# Altium schematic sheet ShapingAmp.SchDoc
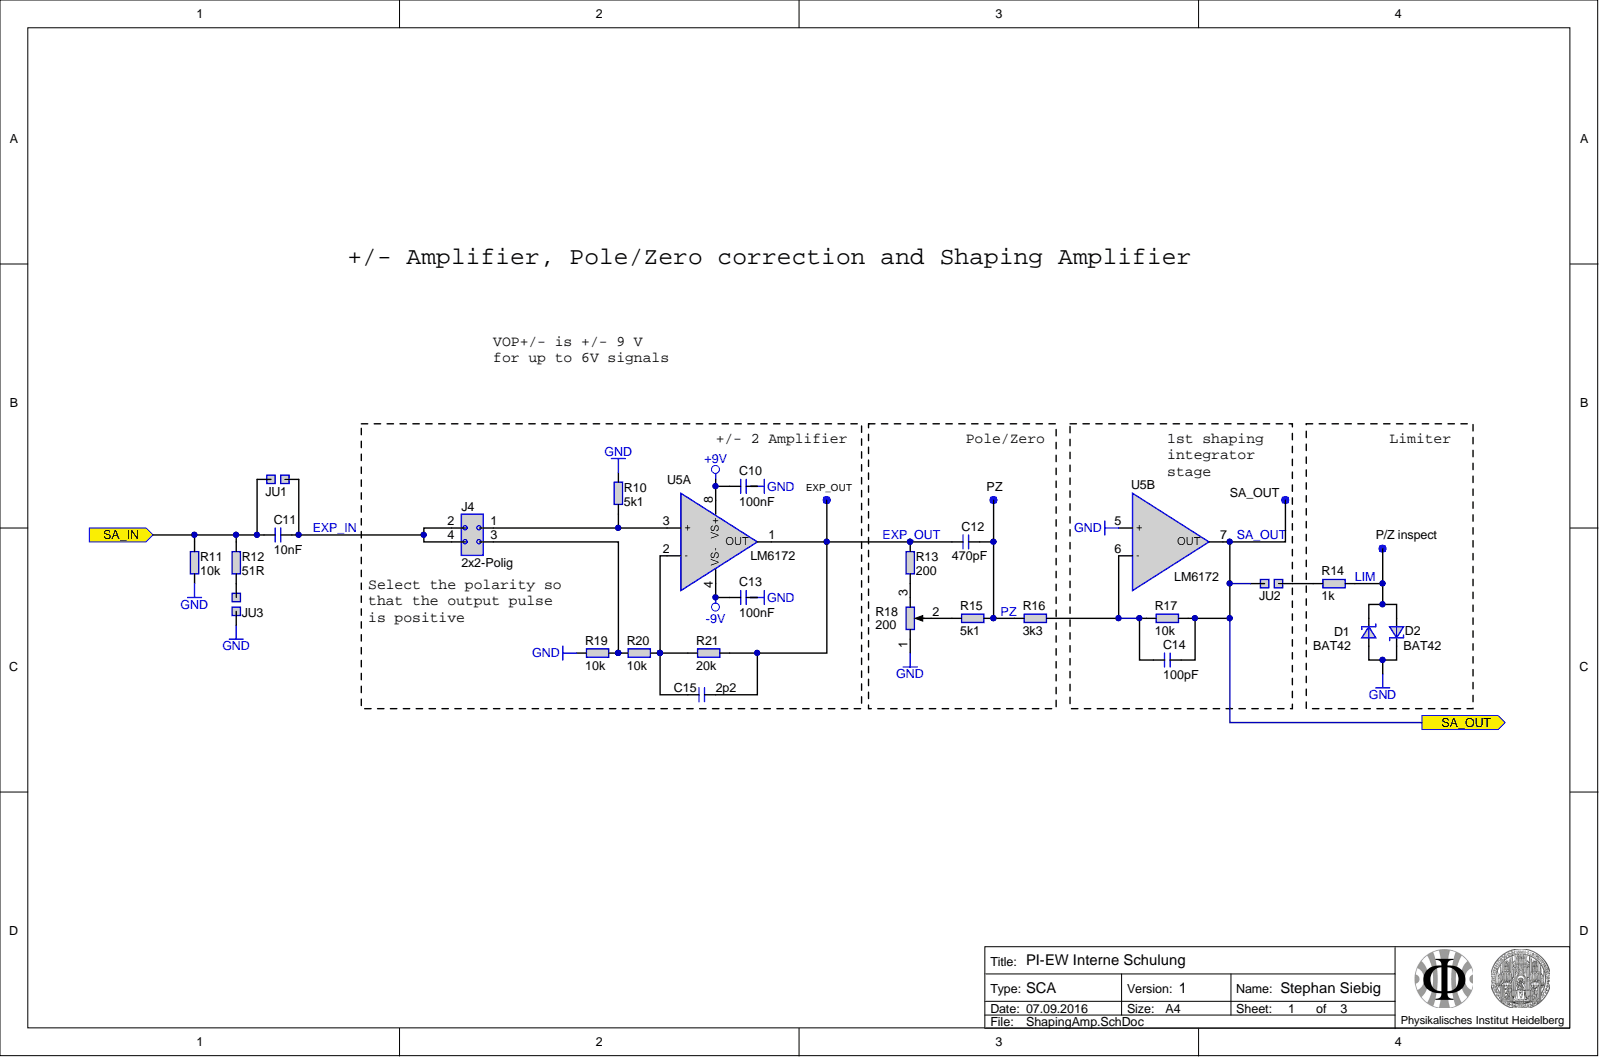
[diagram p0: ShapingAmp.SchDoc]

## Parts
C10  value 100nF  [0805SMD]
C11  value 10nF  [0805SMD]
C12  value 470pF  [0805SMD]
C13  value 100nF  [0805SMD]
C14  value 100pF  [0805SMD]
C15  value 2p2  [0805SMD]
D1  BAT42  [D-1206SMD]
D2  BAT42  [D-1206SMD]
EXP_OUT  PAD  [PAD-2MM_1MM]  (value not drawn)  (no BOM)
J4  value 2x2-Polig  [ST-2X2_2.54MM]  (no BOM)
JU1  (no value)  [ST-1X2_2.54MM]  (no BOM)
JU2  (no value)  [ST-1X2_2.54MM]  (no BOM)
JU3  (no value)  [ST-1X2_2.54MM]  (no BOM)
P/Z_inspect  PAD  [PAD-2MM_1MM]  (value not drawn)  (no BOM)
PZ  PAD  [PAD-2MM_1MM]  (value not drawn)  (no BOM)
R10  value 5k1  [R-0603SMD]
R11  value 10k  [R-0603SMD]
R12  value 51R  [R-0603SMD]
R13  value 200  [R-0603SMD]
R14  value 1k  [R-0603SMD]
R15  value 5k1  [R-0603SMD]
R16  value 3k3  [R-0603SMD]
R17  value 10k  [R-0603SMD]
R18  value 200  [PO-3299W]  (hidden comment: 3266P/W-1-XXX)
R19  value 10k  [R-0603SMD]
R20  value 10k  [R-0603SMD]
R21  value 20k  [R-0603SMD]
SA_OUT  PAD  [PAD-2MM_1MM]  (value not drawn)  (no BOM)
U5  LM6172  [DIP-8]  (parts A,B)

## Ports
SA_IN, SA_OUT

## Nets
+9V: C10.1 U5.8(VS+)
-9V: C13.1 U5.4(VS-)
GND: C10.2 C13.2 D1.2 D2.1 JU3.2 R10.2 R11.1 R18.1 R19.2 U5.5(+)
EXP_IN: C11.2 J4.2 J4.4 JU1.1
EXP_OUT: C12.1 C15.2 EXP_OUT.1 R13.2 R21.1 U5.1(OUT)
LIM: D1.1 D2.2 P/Z_inspect.1 R14.1
PZ: C12.2 PZ.1 R15.1 R16.2
SA_IN: C11.1 JU1.2 R11.2 R12.2 ; port SA_IN
SA_OUT: C14.2 JU2.2 R17.1 SA_OUT.1 U5.7(OUT) ; port SA_OUT
NetC14_1: C14.1 R16.1 R17.2 U5.6(-)
NetC15_1: C15.1 R20.1 R21.2 U5.2(-)
NetJ4_1: J4.1 R10.1 U5.3(+)
NetJ4_3: J4.3 R19.1 R20.2
NetJU2_1: JU2.1 R14.2
NetJU3_1: JU3.1 R12.1
NetR13_1: R13.1 R18.3
NetR15_2: R15.2 R18.2

## Library data of the parts
C10 C13 = CK-SMD: Keramikkondensator; PART NUMBER: 1612; Component Kind: Standard; Library Name: EW.SchLib
C11 = CK-SMD: Keramikkondensator; PART NUMBER: 1611; Component Kind: Standard; Library Name: EW.SchLib
C12 = CK-SMD: Keramikkondensator; PART NUMBER: 2278; Component Kind: Standard; Library Name: EW.SchLib
C14 = CK-SMD: Keramikkondensator; PART NUMBER: 2270; Component Kind: Standard; Library Name: EW.SchLib
C15 = CK-SMD: Keramikkondensator; PART NUMBER: 2250; Component Kind: Standard; Library Name: EW.SchLib
D1 D2 = ZD-SMD: Schottky-Diode; PART NUMBER: 646; Component Kind: Standard; PART TYPE: BAT42; Library Name: EW.SchLib
EXP_OUT P/Z_inspect PZ SA_OUT = PAD_1: Description: PAD; Library Name: EW.SchLib
J4 = ST-2X2: Stiftleiste; PART NUMBER: 6167; Library Name: EW-User.SchLib
JU1-JU3 = J-1X2: Jumper mit 2 Kontakten; PART NUMBER: 2084; VALUE: 1x2; Library Name: EW.SchLib
R10 R12 R14-R16 R21 = R-SMD: Widerstand; Component Kind: Standard; Library Name: EW.SchLib
R11 R17 R19 R20 = R-SMD: Widerstand; PART NUMBER: 6516; Component Kind: Standard; Library Name: EW.SchLib
R13 = R-SMD: Widerstand; PART NUMBER: 2011; Component Kind: Standard; Library Name: EW.SchLib
R18 = PO-3266P/W: Potentiometer; PART NUMBER: 2011; Component Kind: Standard; PART TYPE: 3266P/W-1-XXX; Library Name: EW.SchLib
U5 = OP-STANDARD_2CH_8P: Operationsverstärker 2-Fach; Component Kind: Standard; PART TYPE: LM6172; Library Name: EW.SchLib
